# Altium schematic sheet Sheet1.SchDoc
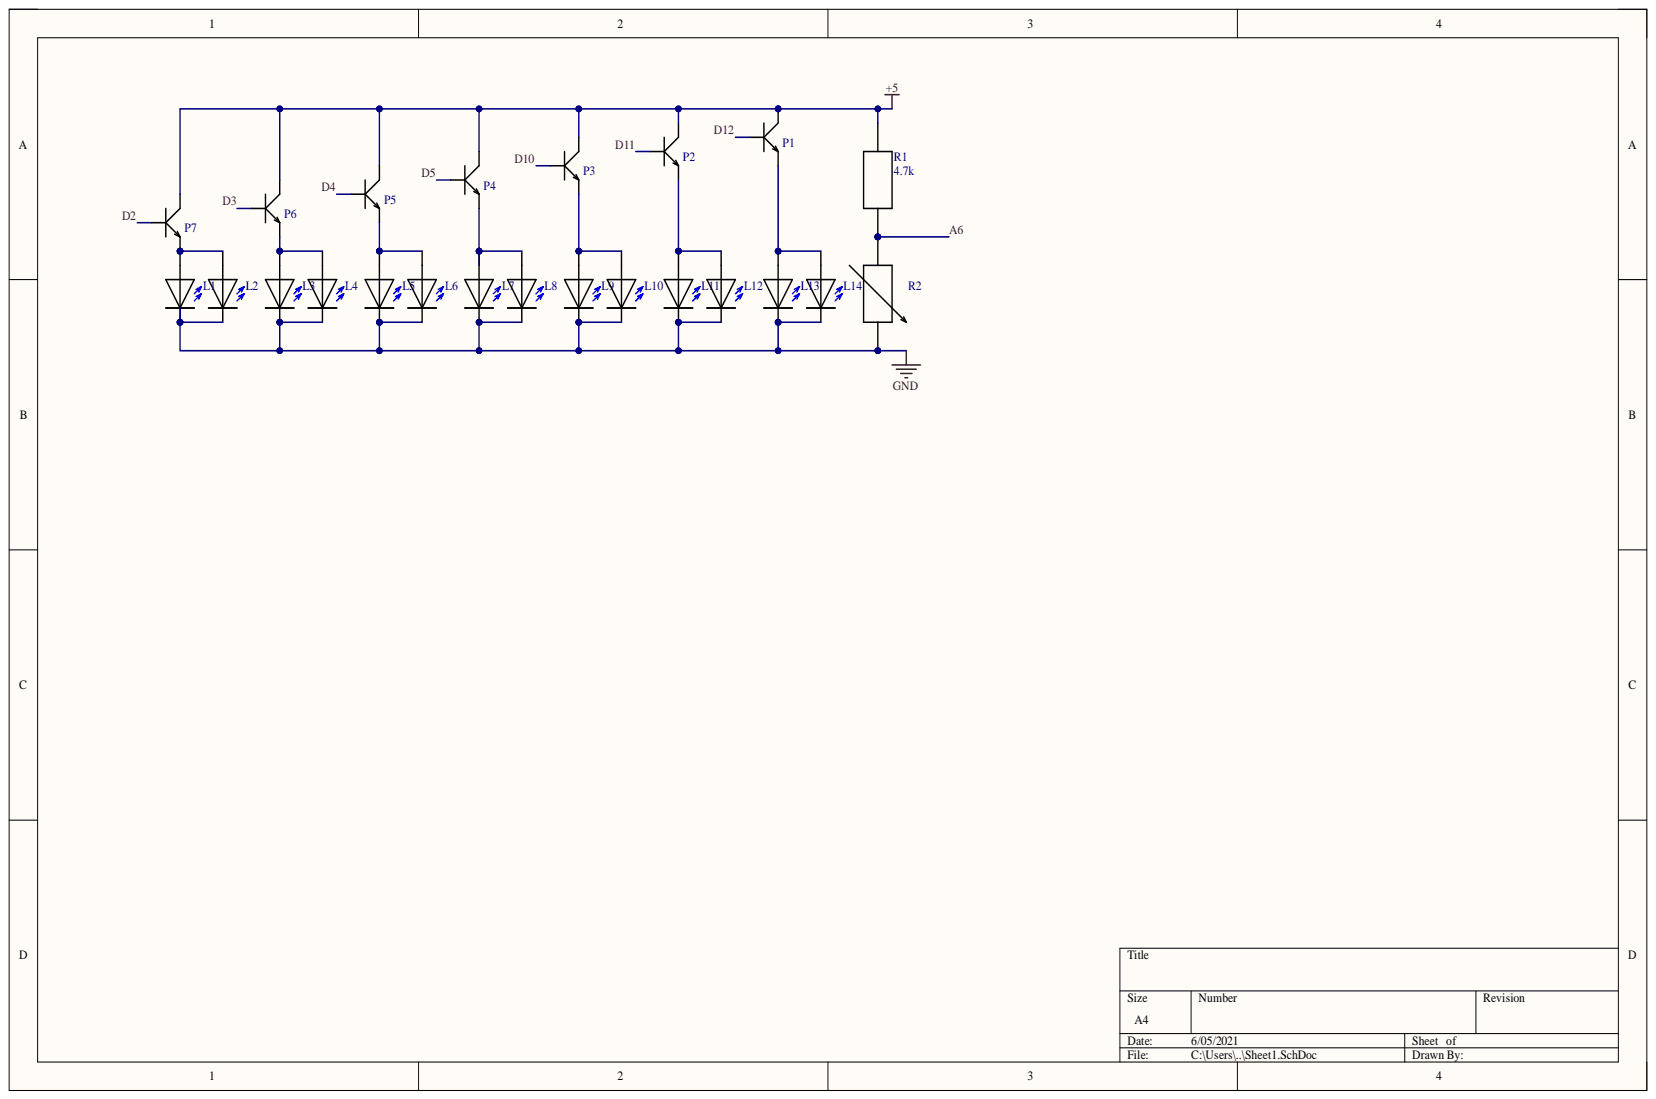
[diagram p0: Sheet1.SchDoc]

## Parts
L1  LED  (value not drawn)
L2  LED  (value not drawn)
L3  LED  (value not drawn)
L4  LED  (value not drawn)
L5  LED  (value not drawn)
L6  LED  (value not drawn)
L7  LED  (value not drawn)
L8  LED  (value not drawn)
L9  LED  (value not drawn)
L10  LED  (value not drawn)
L11  LED  (value not drawn)
L12  LED  (value not drawn)
L13  LED  (value not drawn)
L14  LED  (value not drawn)
P1  Triode  (value not drawn)
P2  Triode  (value not drawn)
P3  Triode  (value not drawn)
P4  Triode  (value not drawn)
P5  Triode  (value not drawn)
P6  Triode  (value not drawn)
P7  Triode  (value not drawn)
R1  4.7k
R2  VarR  (value not drawn)

## Nets
+5: P1.3 P2.3 P3.3 P4.3 P5.3 P6.3 P7.3 R1.5
GND: L1.0 L2.0 L3.0 L4.0 L5.0 L6.0 L7.0 L8.0 L9.0 L10.0 L11.0 L12.0 L13.0 L14.0 R2.5
A6: R1.6 R2.6
D2: P7.2
D3: P6.2
D4: P5.2
D5: P4.2
D10: P3.2
D11: P2.2
D12: P1.2
NetL1_1: L1.1 L2.1 P7.4
NetL3_1: L3.1 L4.1 P6.4
NetL5_1: L5.1 L6.1 P5.4
NetL7_1: L7.1 L8.1 P4.4
NetL9_1: L9.1 L10.1 P3.4
NetL11_1: L11.1 L12.1 P2.4
NetL13_1: L13.1 L14.1 P1.4

## Library data of the parts
R1 = R: Component Kind: Standard; Library Name: Schlib1.SchLib
L1-L14 = LED: Component Kind: Standard; Library Name: Schlib1.SchLib
P1-P7 = Triode: Component Kind: Standard; Library Name: Schlib1.SchLib
R2 = VarR: Component Kind: Standard; Library Name: Schlib1.SchLib
